# Altium schematic sheet Microcontroller.SchDoc
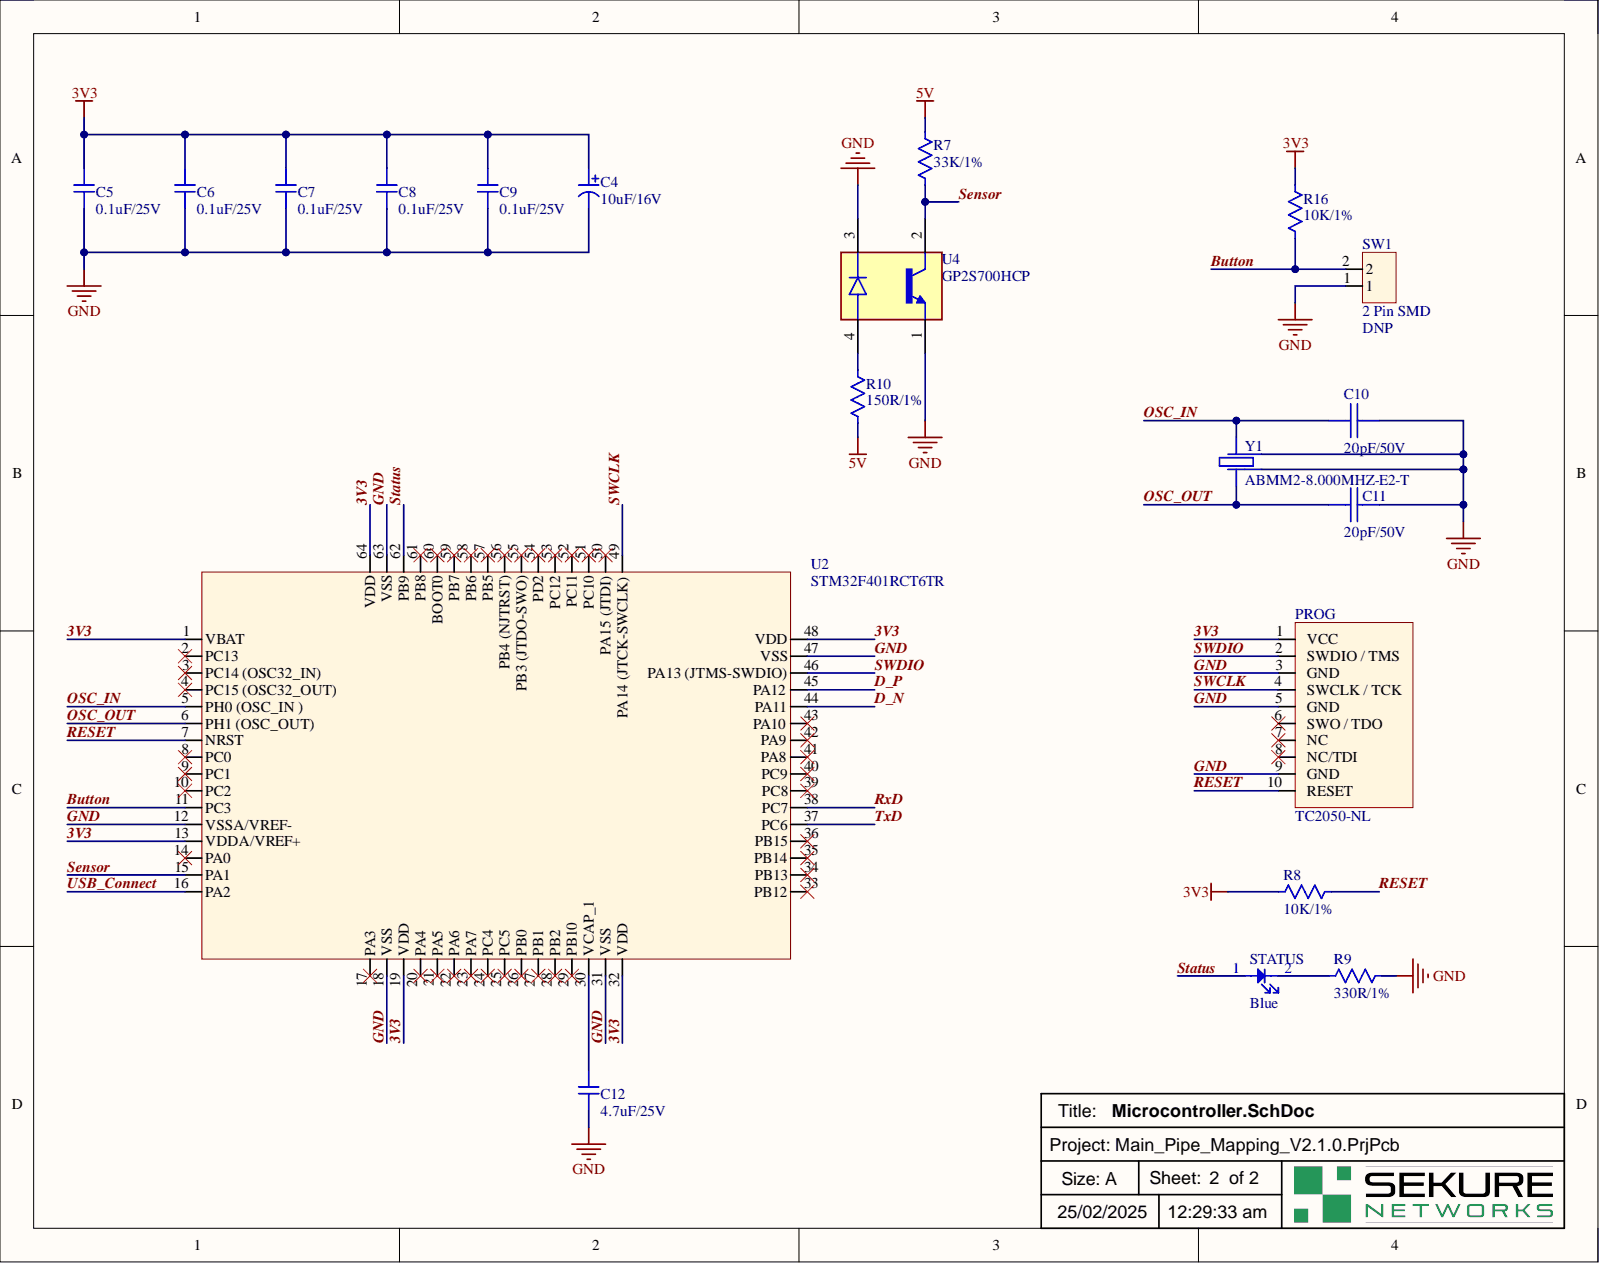
[diagram p0: Microcontroller.SchDoc]

## Parts
C4  value 10uF/16V  [CAPAE4643X55M]  (hidden comment: Capacitor)
C5  value 0.1uF/25V  [CAP 0603]  (hidden comment: Ceramic Capacitor)
C6  value 0.1uF/25V  [CAP 0603]  (hidden comment: Ceramic Capacitor)
C7  value 0.1uF/25V  [CAP 0603]  (hidden comment: Ceramic Capacitor)
C8  value 0.1uF/25V  [CAP 0603]  (hidden comment: Ceramic Capacitor)
C9  value 0.1uF/25V  [CAP 0603]  (hidden comment: Ceramic Capacitor)
C10  value 20pF/50V  [CAP 0603]  (hidden comment: Ceramic Capacitor)
C11  value 20pF/50V  [CAP 0603]  (hidden comment: Ceramic Capacitor)
C12  value 4.7uF/25V  [CAP 0603]  (hidden comment: Ceramic Capacitor)
PROG  value TC2050-NL  [TC2050_full]  (hidden comment: Programming Connector)
R7  value 33K/1%  [RES 0603]  (hidden comment: Resistor)
R8  value 10K/1%  [RES 0603]  (hidden comment: Resistor)
R9  value 330R/1%  [RES 0603]  (hidden comment: Resistor)
R10  value 150R/1%  [RES 0603]  (hidden comment: Resistor)
R16  value 10K/1%  [RES 0603]  (hidden comment: Resistor)
STATUS  value Blue  Color: Blue  [APT1608SURCK]  (hidden comment: LED)
SW1  value 2 Pin SMD  Assembly: DNP  [2 Pin SMD]  (hidden comment: Connector)
U2  Microcontroller  value STM32F401RCT6TR  [LQFP64-1000X1000X160-64N]
U4  Sensor  value GP2S700HCP  [GP2S700HCP]
Y1  value ABMM2-8.000MHZ-E2-T  [ABMM2-8.000MHZ-E2-T]  (hidden comment: Crystal)

## Nets
5V: R7.2 R10.1
3V3: C4.1 C5.1 C6.1 C7.1 C8.1 C9.1 PROG.1(VCC) R8.1 R16.1 U2.1(VBAT) U2.13(VDDA/VREF+) U2.19(VDD) U2.32(VDD) U2.48(VDD) U2.64(VDD)
Button: R16.2 SW1.2 U2.11(PC3)
D_N: U2.44(PA11)
D_P: U2.45(PA12)
GND: C4.2 C5.2 C6.2 C7.2 C8.2 C9.2 C10.2 C11.2 C12.1 PROG.3(GND) PROG.5(GND) PROG.9(GND) R9.1 SW1.1 U2.12(VSSA/VREF-) U2.18(VSS) U2.31(VSS) U2.47(VSS) U2.63(VSS) U4.1 U4.3 Y1.2 Y1.4
OSC_IN: C10.1 U2.5(PH0_(OSC_IN_)) Y1.3
OSC_OUT: C11.1 U2.6(PH1_(OSC_OUT)) Y1.1
RESET: PROG.10(RESET) R8.2 U2.7(NRST)
RxD: U2.38(PC7)
Sensor: R7.1 U2.15(PA1) U4.2
Status: STATUS.1 U2.62(PB9)
SWCLK: PROG.4(SWCLK_/_TCK) U2.49(PA14_(JTCK-SWCLK))
SWDIO: PROG.2(SWDIO_/_TMS) U2.46(PA13_(JTMS-SWDIO))
TxD: U2.37(PC6)
USB_Connect: U2.16(PA2)
NetC12_2: C12.2 U2.30(VCAP_1)
NetR9_2: R9.2 STATUS.2
NetR10_2: R10.2 U4.4

Not connected: PROG 6(SWO_/_TDO) 7(NC) 8(NC/TDI); U2 2(PC13) 3(PC14_(OSC32_IN)) 4(PC15_(OSC32_OUT)) 8(PC0) 9(PC1) 10(PC2) 14(PA0) 17(PA3) 20(PA4) 21(PA5) 22(PA6) 23(PA7) 24(PC4) 25(PC5) 26(PB0) 27(PB1) 28(PB2) 29(PB10) 33(PB12) 34(PB13) 35(PB14) 36(PB15) 39(PC8) 40(PC9) 41(PA8) 42(PA9) 43(PA10) 50(PA15_(JTDI)) 51(PC10) 52(PC11) 53(PC12) 54(PD2) 55(PB3_(JTDO-SWO)) 56(PB4_(NJTRST)) 57(PB5) 58(PB6) 59(PB7) 60(BOOT0) 61(PB8)

## Library data of the parts
C4 = 865080340001: Cap, 10ΜF, 16V, SMD; Manufacturer: Wurth Electronics; Capacitance: 10uF; Impedance: 2.2R; Life (Hours): 2000Hour; Polarity: Polar; Ripple Current: 80mA; Tolerance: 20%; Case/Package: Radial; Category: Aluminum Electrolytic Capacitors; Component Kind: Standard; ComponentLink1Description: http://www.farnell.com/datasheets/1880118.pdf?src-supplier=Farnell; ComponentLink1URL: http://www.farnell.com/datasheets/1880118.pdf?src-supplier=Farnell; ComponentLink2Description: http://www.farnell.com/datasheets/1880118.pdf?src-supplier=Element14; ComponentLink2URL: http://www.farnell.com/datasheets/1880118.pdf?src-supplier=Element14; ComponentLink3Description: http://www.farnell.com/datasheets/1880118.pdf?src-supplier=Newark; ComponentLink3URL: http://www.farnell.com/datasheets/1880118.pdf?src-supplier=Newark; ComponentLink4Description: https://katalog.we-online.de/pbs/datasheet/865080340001.pdf?src-supplier=Digi-Key; ComponentLink4URL: https://katalog.we-online.de/pbs/datasheet/865080340001.pdf?src-supplier=Digi-Key; Diameter: 4mm; DigiKey: 732-8411-1-ND; Height: 5.5mm; Height - Seated (Max): 0.217inch; Lead Free: Lead Free; Library Name: Radial Capacitors.IntLib; Max Operating Temperature: 105°C; Min Operating Temperature: -55°C; Mount: Surface Mount; P/N: 865080340001; Package Quantity: 2000; Packaging: Cut Tape; Pricing 1: 1=0.18000, 50=0.15100, 100=0.13100, 250=0.11700, 500=0.10200, 1000=0.09700 (USD); REACH SVHC: Unknown; Ripple Current (AC): 80mA; RoHS: Yes; RoHS Compliant: Yes; Stock 1: 11596; Supplier 1: Digi-Key; Voltage Rating: 16V; Voltage Rating (DC): 16V
C5-C9 = CL10B104KA8NNNC: Multilayer Ceramic Capacitor, 0.1 uF, 25 V, ± 10%, X7R, 0603 [1608 Metric]; Manufacturer: Samsung; Material: 4241ConductorMaterial; Voltage: 25V; Case Code (Imperial): 0603; Case Code (Metric): 1608; Case/Package: 0603; Category: Ceramic Capacitors; Component Kind: Standard; ComponentLink1Description: https://datasheet.ciiva.com/25805/cl10b104ka8nnnc-25805120.pdf?src-supplier=Digikey; ComponentLink1URL: https://datasheet.ciiva.com/25805/cl10b104ka8nnnc-25805120.pdf?src-supplier=Digikey; ComponentLink2Description: https://datasheet.ciiva.com/26838/getdatasheetpartid-354050-26838409.pdf?src-supplier=Verical; ComponentLink2URL: https://datasheet.ciiva.com/26838/getdatasheetpartid-354050-26838409.pdf?src-supplier=Verical; Depth: 0.8mm; Dielectric: X7R; DigiKey: 1276-1006-1-ND; Height: 0.8mm; Lead Free: Lead Free; Length: 1.6mm; Library Name: Ceramic Capacitors.IntLib; Max Operating Temperature: 125°C; Min Operating Temperature: -55°C; Mounting Technology: Surface Mount; P/N: CL10B104KA8NNNC; Package Quantity: 1; Packaging: Tape and Reel; Pricing: 1=0.1, 10=0.024, 50=0.0136, 100=0.0105, 500=0.00792, 1000=0.00622 (USD); Radiation Hardening: No; RoHS: Yes; RoHS Compliant: Yes; Series: CL; Stock: 708971; Supplier: Digikey; Thickness: 0.035inch; Tolerance: 10%; Voltage Rating: 25V; Voltage Rating (DC): 25V; Width: 0.031inch
C10 C11 = CC0603GRNPO9BN200: CAP CER 20PF 50V NPO 0603; Manufacturer 1: Yageo; Dielectric: NP0; Insulation Resistance: 10GR; Tolerance: 2%; Capacitance: 20pF; Case Code (Imperial): 0603; Case Code (Metric): 1608; Case/Package: 0603; Component Kind: Standard; ComponentLink1Description: https://datasheet.ciiva.com/13264/upy-gp-np0-16v-to-50v-8-3714-13264457.pdf?src-supplier=Mouser; ComponentLink1URL: https://datasheet.ciiva.com/13264/upy-gp-np0-16v-to-50v-8-3714-13264457.pdf?src-supplier=Mouser; DigiKey: 311-3924-1-ND; Lead Free: Lead Free; Length: 0.063inch; Library Name: Ceramic Capacitors.IntLib; Max Operating Temperature: 125°C; Min Operating Temperature: -55°C; Mount: Surface Mount; P/N: CC0603GRNPO9BN200; Packaging: Tape and Reel; Pricing 1: 1=0.14000, 10=0.09900, 50=0.05640, 100=0.04680, 500=0.03280, 1000=0.02715 (USD); Resistor Type: Ceramic; RoHS: Yes; RoHS Compliant: Yes; Series: CC; Stock 1: 53; Supplier 1: Digi-Key; Termination: SMD/SMT; Thickness: 0.035inch; Voltage Rating: 50V; Voltage Rating (DC): 50V; Weight: 7.1E-05oz; Width: 0.031inch
C12 = CL10A475MA8NQNC: Ceramic Capacitor, Multilayer, Ceramic, 25V, 20% +Tol, 20% -Tol, X5R, 15% TC, 4.7uF, Surface Mount, 0603; Manufacturer: Samsung; Multilayer: Yes; Capacitor Type: Ceramic; Case/Package: 0603; Category: Ceramic Capacitors; Component Kind: Standard; ComponentLink1Description: https://datasheet.ciiva.com:443/pdfs/VipMasterIC/IC/SEMS/SEMS-S-A0008384515/SEMS-S-A0008873310-1.pdf?src-supplier=IHS+Markit; ComponentLink1URL: https://datasheet.ciiva.com:443/pdfs/VipMasterIC/IC/SEMS/SEMS-S-A0008384515/SEMS-S-A0008873310-1.pdf?src-supplier=IHS+Markit; ComponentLink2Description: https://datasheet.ciiva.com/25787/cl10a475ma8nqnc-25787184.pdf?src-supplier=Digi-Key; ComponentLink2URL: https://datasheet.ciiva.com/25787/cl10a475ma8nqnc-25787184.pdf?src-supplier=Digi-Key; ComponentLink3Description: https://datasheet.ciiva.com/16437/cl10a475ma8nqnc-16437322.pdf?src-supplier=Future+Electronics; ComponentLink3URL: https://datasheet.ciiva.com/16437/cl10a475ma8nqnc-16437322.pdf?src-supplier=Future+Electronics; Dielectric Material: Ceramic; DigiKey: 1276-1903-1-ND; JESD-609 Code: e3; Library Name: Ceramic Capacitors.IntLib; Max Operating Temperature: 85°C; Min Operating Temperature: -55°C; Mounting Technology: Surface Mount; P/N: CL10A475MA8NQNC; Package Shape: Rectangular; Packaging: Tape and Reel; Pins: 2; Pricing: 1=0.27, 10=0.187, 50=0.1244, 100=0.1058, 500=0.07466, 1000=0.06533 (USD); Rated DC Voltage (URdc): 25V; Reach SVHC Compliant: Yes; RoHS: Yes; RoHS Compliant: Yes; Stock: 1889613; Supplier: Digi-Key; Temperature Characteristics Code: X5R; Terminal Finish: Nickel; Termination: Wraparound; Tolerance  (fill in as +-): 20%; Tolerance (fill in as +-): 20%
PROG = TC2050_Full: “No Legs” 10-pin Plug-of-Nails™ Cable fitted with a 10-pin 0.1″ pitch ribbon connector.; Assembly: DNP; Component Kind: Standard; Library Name: Programming Connectors.IntLib
R7 = RC0603FR-0733KL: SMD Chip Resistor, 33 kOhm, ï¿½ 1%, 100 mW, 0603 [1608 Metric], Thick Film, General Purpose; Manufacturer: Yageo; Max Power Dissipation: 100mW; Temperature Coefficient: 100ppm/°C; Voltage Rating: 50V; Case Code (Imperial): 0603; Case Code (Metric): 1608; Case/Package: 0603; Category: Chip SMD Resistors; Component Kind: Standard; ComponentLink1Description: https://datasheet.ciiva.com/46395/443971-46395005.pdf?src-supplier=Element14; ComponentLink1URL: https://datasheet.ciiva.com/46395/443971-46395005.pdf?src-supplier=Element14; ComponentLink2Description: https://datasheet.ciiva.com/2454/pyu-r-int-thick-7-2454010.pdf?src-supplier=Digikey; ComponentLink2URL: https://datasheet.ciiva.com/2454/pyu-r-int-thick-7-2454010.pdf?src-supplier=Digikey; ComponentLink3Description: https://datasheet.ciiva.com/2454/pyu-r-int-thick-7-2454011.pdf?src-supplier=Digikey; ComponentLink3URL: https://datasheet.ciiva.com/2454/pyu-r-int-thick-7-2454011.pdf?src-supplier=Digikey; ComponentLink4Description: https://datasheet.ciiva.com/2454/pyu-r-int-thick-7-2454009.pdf?src-supplier=Digikey; ComponentLink4URL: https://datasheet.ciiva.com/2454/pyu-r-int-thick-7-2454009.pdf?src-supplier=Digikey; ComponentLink5Description: https://datasheet.ciiva.com/7746/443971-7746332.pdf?src-supplier=Element14; ComponentLink5URL: https://datasheet.ciiva.com/7746/443971-7746332.pdf?src-supplier=Element14; ComponentLink6Description: https://datasheet.ciiva.com/7746/443971-7746333.pdf?src-supplier=Element14; ComponentLink6URL: https://datasheet.ciiva.com/7746/443971-7746333.pdf?src-supplier=Element14; ComponentLink7Description: https://datasheet.ciiva.com/15189/getdatasheetpartid-353493-15189706.pdf?src-supplier=Verical; ComponentLink7URL: https://datasheet.ciiva.com/15189/getdatasheetpartid-353493-15189706.pdf?src-supplier=Verical; Construction: Rectangular; Depth: 0.8mm; DigiKey: 311-33.0KHRCT-ND; Features: Moisture Resistant; Height: 0.022inch; Lead Free: 5697LeadFree; Length: 0.063inch; Library Name: Resistors.IntLib; Max Operating Temperature: 155°C; Military Standard: Not; Min Operating Temperature: -55°C; Number of Terminations: 2; P/N: RC0603FR-0733KL; Package Quantity: 1; Packaging: Tape and Reel; Pins: 2; Power Rating: 100mW; Pricing: 1=0.1, 10=0.008, 100=0.0071, 1000=0.00612, 2500=0.00461 (USD); Reach SVHC Compliant: No; Resistance Tolerance: 1%; Resistor Type: Thick Film; RoHS: Yes; RoHS Compliant: Yes; Series: RC; Stock: 635659; Supplier: Digikey; Termination: SMD/SMT; Tolerance: 1%; Voltage Rating (AC): 50V; Voltage Rating (DC): 50V; Width: 0.031inch
R8 R16 = RC0603FR-0710KL: RES SMD 10K OHM 1% 1/10W 0603; Manufacturer: Yageo; Power Rating: 100mW; Resistance: 10kR; Temperature Coefficient: 100ppm/°C; Voltage Rating: 75V; Voltage Rating (DC): 50V; Case Code (Imperial): 0603; Case Code (Metric): 1608; Case/Package: 0603; Component Kind: Standard; ComponentLink1Description: https://datasheet.ciiva.com/7660/38590-7660789.pdf?src-supplier=Element14; ComponentLink1URL: https://datasheet.ciiva.com/7660/38590-7660789.pdf?src-supplier=Element14; ComponentLink2Description: https://datasheet.ciiva.com/15189/getdatasheetpartid-409289-15189591.pdf?src-supplier=Verical; ComponentLink2URL: https://datasheet.ciiva.com/15189/getdatasheetpartid-409289-15189591.pdf?src-supplier=Verical; ComponentLink3Description: https://datasheet.ciiva.com/46440/1868787-46440891.pdf?src-supplier=Element14; ComponentLink3URL: https://datasheet.ciiva.com/46440/1868787-46440891.pdf?src-supplier=Element14; ComponentLink4Description: https://datasheet.ciiva.com/7983/443971-7983596.pdf?src-supplier=Element14; ComponentLink4URL: https://datasheet.ciiva.com/7983/443971-7983596.pdf?src-supplier=Element14; ComponentLink5Description: https://datasheet.ciiva.com/7746/1738695-7746239.pdf?src-supplier=Element14; ComponentLink5URL: https://datasheet.ciiva.com/7746/1738695-7746239.pdf?src-supplier=Element14; ComponentLink6Description: https://datasheet.ciiva.com/22747/443971-22747519.pdf?src-supplier=Element14; ComponentLink6URL: https://datasheet.ciiva.com/22747/443971-22747519.pdf?src-supplier=Element14; Composition: Thick Film; Depth: 0.8mm; DigiKey: 311-10.0KHRDKR-ND; Height: 0.45mm; Lead Free: Lead Free; Lead Pitch: 0.3mm; Length: 1.6mm; Library Name: Resistors.IntLib; Max Operating Temperature: 155°C; Military Standard: Not; Min Operating Temperature: -55°C; Number of Pins: 2; P/N: RC0603FR-0710KL; Packaging: Tape and Reel; Pricing 1: 1=0.10000, 10=0.02300, 100=0.00940, 1000=0.00421, 2500=0.00366 (USD); REACH SVHC: No SVHC; RoHS: Yes; Stock 1: 55833360; Supplier 1: Digi-Key; Termination: SMD/SMT; Tolerance: 1%; Weight: 7.1E-05oz; Width: 0.8mm
R9 = ERJ3EKF3300V: RES SMD 330 OHM 1% 1/10W 0603; Manufacturer: Panasonic; Max Power Dissipation: 100mW; Resistance: 330R; Temperature Coefficient: 100ppm/°C; Voltage Rating: 75V; Case Code (Imperial): 0603; Case Code (Metric): 1608; Case/Package: 0603; Component Kind: Standard; ComponentLink1Description: https://datasheet.ciiva.com/48531/aoa0000ce2-48531001.pdf?src-supplier=Avnet; ComponentLink1URL: https://datasheet.ciiva.com/48531/aoa0000ce2-48531001.pdf?src-supplier=Avnet; ComponentLink2Description: https://datasheet.ciiva.com/22721/426256-22721099.pdf?src-supplier=Element14; ComponentLink2URL: https://datasheet.ciiva.com/22721/426256-22721099.pdf?src-supplier=Element14; ComponentLink3Description: https://datasheet.ciiva.com/46373/1678782-46373899.pdf?src-supplier=Element14; ComponentLink3URL: https://datasheet.ciiva.com/46373/1678782-46373899.pdf?src-supplier=Element14; ComponentLink4Description: https://datasheet.ciiva.com/7886/aoa0000ce2-7886729.pdf?src-supplier=Element14; ComponentLink4URL: https://datasheet.ciiva.com/7886/aoa0000ce2-7886729.pdf?src-supplier=Element14; ComponentLink5Description: https://datasheet.ciiva.com/9117/aoa0000ce2-9117132.pdf?src-supplier=Avnet; ComponentLink5URL: https://datasheet.ciiva.com/9117/aoa0000ce2-9117132.pdf?src-supplier=Avnet; ComponentLink6Description: https://datasheet.ciiva.com/46373/1678782-46373900.pdf?src-supplier=Element14; ComponentLink6URL: https://datasheet.ciiva.com/46373/1678782-46373900.pdf?src-supplier=Element14; Composition: Thick Film; Contact Plating: Tin; Depth: 0.8mm; DigiKey: P330HCT-ND; Features: Automotive AEC-Q200; Height: 0.45mm; Lead Free: Lead Free; Length: 1.6mm; Library Name: Resistors.IntLib; Max Operating Temperature: 155°C; Military Standard: Not; Min Operating Temperature: -55°C; Mount: Surface Mount; Number of Pins: 2; Number of Terminations: 2; P/N: ERJ3EKF3300V; Package Quantity: 5000; Packaging: Tape and Reel; Power Rating: 100mW; Pricing 1: 1=0.10000, 10=0.07000, 100=0.02840, 1000=0.01275, 2500=0.01106 (USD); Radiation Hardening: No; REACH SVHC: No SVHC; RoHS: Yes; Stock 1: 1950276; Supplier 1: Digi-Key; Termination: SMD/SMT; Tolerance: 1%; Voltage Rating (DC): 75V; Weight: 7.1E-05oz; Width: 0.8mm
R10 = RMCF0603FT150R: Res Thick Film 0603 150 Ohm 1% 0.1W(1/10W) ±100ppm/C Pad SMD Automotive T/R; Manufacturer: Stackpole Electronics; Max Power Dissipation: 100mW; Resistance: 150R; Temperature Coefficient: 100ppm/°C; Case Code (Imperial): 0603; Case Code (Metric): 1608; Case/Package: 0603; Category: Chip SMD Resistors; Component Kind: Standard; ComponentLink1Description: http://components.arrow.com/part/dataview/44988197S7171982N1174?src-supplier=Arrow+Electronics; ComponentLink1URL: http://components.arrow.com/part/dataview/44988197S7171982N1174?src-supplier=Arrow+Electronics; ComponentLink2Description: https://www.seielect.com/catalog/SEI-RMCF_RMCP.pdf?src-supplier=Avnet; ComponentLink2URL: https://www.seielect.com/catalog/SEI-RMCF_RMCP.pdf?src-supplier=Avnet; ComponentLink3Description: https://www.seielect.com/catalog/SEI-Packaging.pdf?src-supplier=Digi-Key; ComponentLink3URL: https://www.seielect.com/catalog/SEI-Packaging.pdf?src-supplier=Digi-Key; ComponentLink4Description: https://www.seielect.com/catalog/SEI-RMCF_RMCP.pdf?src-supplier=Avnet+Asia; ComponentLink4URL: https://www.seielect.com/catalog/SEI-RMCF_RMCP.pdf?src-supplier=Avnet+Asia; ComponentLink5Description: http://www.seielect.com/Catalog/SEI-RMC.pdf?src-supplier=Future+Electronics; ComponentLink5URL: http://www.seielect.com/Catalog/SEI-RMC.pdf?src-supplier=Future+Electronics; Contact Plating: Tin,Matte; DigiKey: RMCF0603FT150RCT-ND; Features: Automotive AEC-Q200; Height: 0.022inch; Lead Free: Lead Free; Length: 0.061inch; Library Name: Resistors.IntLib; Max Operating Temperature: 155°C; Min Operating Temperature: -55°C; Mount: Surface Mount; Number of Terminations: 2; P/N: RMCF0603FT150R; Packaging: Tape and Reel; Power Rating: 100mW; Pricing 1: 1=0.10000, 10=0.01700, 100=0.00680, 1000=0.00305, 2500=0.00264 (USD); Radiation Hardening: No; Resistor Type: Thick Film; RoHS: Yes; RoHS Compliant: Yes; Series: RMCF; Size Code: 0603; Stock 1: 593090; Supplier 1: Digi-Key; Tolerance: 1%; Width: 0.031inch
STATUS = APT1608QBC/D: Single Color LED, Blue, Water Clear, 1.2mm; Manufacturer: Kingbright; Channels: 1; Forward Current: 30mA; Luminous Intensity-Nom: 100mcd; Overall Height: 0.75mm; Shape: Rectangular; Viewing Angle: 120°; Category: LEDs; Component Kind: Standard; ComponentLink1Description: https://datasheet.ciiva.com:443/pdfs/VipMasterIC/IC/KNGB/KNGBS06436/KNGBS06436-1.pdf?src-supplier=IHS+Markit; ComponentLink1URL: https://datasheet.ciiva.com:443/pdfs/VipMasterIC/IC/KNGB/KNGBS06436/KNGBS06436-1.pdf?src-supplier=IHS+Markit; ComponentLink2Description: https://datasheet.ciiva.com/12403/apt1608qbc-d-65281-12403861.pdf?src-supplier=Mouser; ComponentLink2URL: https://datasheet.ciiva.com/12403/apt1608qbc-d-65281-12403861.pdf?src-supplier=Mouser; ComponentLink3Description: https://datasheet.ciiva.com/2121/apt1608qbc-d-2121092.pdf?src-supplier=Digikey; ComponentLink3URL: https://datasheet.ciiva.com/2121/apt1608qbc-d-2121092.pdf?src-supplier=Digikey; ComponentLink4Description: https://datasheet.ciiva.com/2121/apt1608qbc-d-2121093.pdf?src-supplier=Digikey; ComponentLink4URL: https://datasheet.ciiva.com/2121/apt1608qbc-d-2121093.pdf?src-supplier=Digikey; ComponentLink5Description: https://datasheet.ciiva.com/2121/apt1608qbc-d-2121094.pdf?src-supplier=Digikey; ComponentLink5URL: https://datasheet.ciiva.com/2121/apt1608qbc-d-2121094.pdf?src-supplier=Digikey; DigiKey: 754-1434-1-ND; Lens Style: WATER CLEAR; Library Name: LEDs.IntLib; Max Operating Temperature: 85°C; Min Operating Temperature: -40°C; Mounting Technology: Surface Mount; Optoelectronic Device Type: SINGLE COLOR LED; P/N: APT1608QBC/D; Packaging: Tape and Reel; Pins: 2; Pricing: 1=0.43, 10=0.263, 100=0.151, 1000=0.11817 (USD); RoHS: Yes; RoHS Compliant: Yes; Size: 1.2mm; Stock: 112434; Supplier: Digikey; Terminal Pitch: 1.65mm
SW1 = 2 Pin SMD: Component Kind: Standard; Description: 2 Pin SMD; Library Name: Connectors.IntLib
U2 = STM32F401RCT6TR: MCU 32-Bit STM32F ARM Cortex M4 RISC 256KB Flash 1.8V/2.5V/3.3V 64-Pin LQFP T/R; Manufacturer 1: STMicroelectronics; Data Bus Width: 32b; Frequency: 84MHz; Interface: I2C,SPI,USB,IrDA,SDIO,UART,USART,LIN,I2S; Max Supply Voltage: 3.6V; Min Supply Voltage: 1.7V; Number of I/Os: 50; Number of Timers/Counters: 8; Operating Supply Voltage: 3.3V; Oscillator Type: Internal; Peripherals: Brown-out Detect/Reset,POR,PWM,WDT,DMA; RAM Size: 64KB; Watchdog Timer: Yes; Case/Package: LQFP; Component Kind: Standard; ComponentLink1Description: https://datasheet.ciiva.com/48494/dm00086815-48494022.pdf?src-supplier=Avnet; ComponentLink1URL: https://datasheet.ciiva.com/48494/dm00086815-48494022.pdf?src-supplier=Avnet; Contact Plating: Tin,Matte; Core Architecture: ARM; DigiKey: 497-19344-1-ND; Library Name: Microcontrollers.IntLib; Max Frequency: 84MHz; Max Operating Temperature: 85°C; Memory Size: 256KB; Memory Type: FLASH; Min Operating Temperature: -40°C; Mount: Surface Mount; Number of Pins: 64; Number of Programmable I/O: 50; P/N: STM32F401RCT6TR; Package Quantity: 1000; Packaging: Tape and Reel; Pricing 1: 1=6.77000, 10=6.08300, 25=5.75120, 100=4.98420, 250=4.72860, 500=4.24296 (USD); Radiation Hardening: No; RoHS: Yes; RoHS Compliant: Yes; Stock 1: 0; Supplier 1: Digi-Key
U4 = GP2S700HCP: Photointerrupter Focal Length 3.0mm; Manufacturer: Socle Technology; Component Kind: Standard; DigiKey: 1855-1020-2-ND; Library Name: Sensors.IntLib; P/N: GP2S700HCP; Pricing: 1000=0.69762, 2000=0.64981, 3000=0.62335, 5000=0.5915, 7000=0.5714, 10000=0.55082 (USD); RoHS: No; Stock: 15000; Supplier: Digikey
Y1 = ABMM2-8.000MHZ-E2-T: ABMM2-8.000MHZ-E2-T - Crystal, 8 MHz, SMD, 6mm x 3.6mm, 20 ppm, 18 pF, 20 ppm, ABMM2 Series; Manufacturer: Abracon; Accuracy: 20ppm; ESR (Equivalent Series Resistance): 72R; Frequency: 8MHz; Load Capacitance: 18pF; Case/Package: CSMD; Component Kind: Standard; ComponentLink1Description: https://datasheet.ciiva.com/7998/1682206-7998656.pdf?src-supplier=Element14; ComponentLink1URL: https://datasheet.ciiva.com/7998/1682206-7998656.pdf?src-supplier=Element14; ComponentLink2Description: https://datasheet.ciiva.com/4945/abmm2-40161-4945441.pdf?src-supplier=Mouser; ComponentLink2URL: https://datasheet.ciiva.com/4945/abmm2-40161-4945441.pdf?src-supplier=Mouser; ComponentLink3Description: https://datasheet.ciiva.com/3176/abmm2-3176155.pdf?src-supplier=Avnet; ComponentLink3URL: https://datasheet.ciiva.com/3176/abmm2-3176155.pdf?src-supplier=Avnet; ComponentLink4Description: https://datasheet.ciiva.com/855/abmm2-855407.pdf?src-supplier=Digi-Key; ComponentLink4URL: https://datasheet.ciiva.com/855/abmm2-855407.pdf?src-supplier=Digi-Key; ComponentLink5Description: https://datasheet.ciiva.com/855/abmm2-855405.pdf?src-supplier=Digi-Key; ComponentLink5URL: https://datasheet.ciiva.com/855/abmm2-855405.pdf?src-supplier=Digi-Key; ComponentLink6Description: https://datasheet.ciiva.com/855/abmm2-855406.pdf?src-supplier=Digi-Key; ComponentLink6URL: https://datasheet.ciiva.com/855/abmm2-855406.pdf?src-supplier=Digi-Key; Composition: Ceramic; Depth: 3.6mm; DigiKey: 535-9628-1-ND; Frequency Stability: 20ppm; Frequency Tolerance: 20ppm; Height: 0.047inch; Lead Free: Lead Free; Length: 6mm; Library Name: Crystals.IntLib; Max Operating Temperature: 70°C; Min Operating Temperature: -20°C; Number of Pins: 4; P/N: ABMM2-8.000MHZ-E2-T; Package Quantity: 1000; Packaging: Tape and Reel; Pricing 1: 1=0.97000, 10=0.86000, 50=0.80420, 100=0.71060, 500=0.67320 (USD); REACH SVHC: No SVHC; RoHS: Yes; Series Resistance: 72R; Stock 1: 70384; Supplier 1: Digi-Key; Termination: SMD/SMT; Tolerance: 20ppm; Width: 3.6mm
